# Altium schematic sheet power.SchDoc(power)
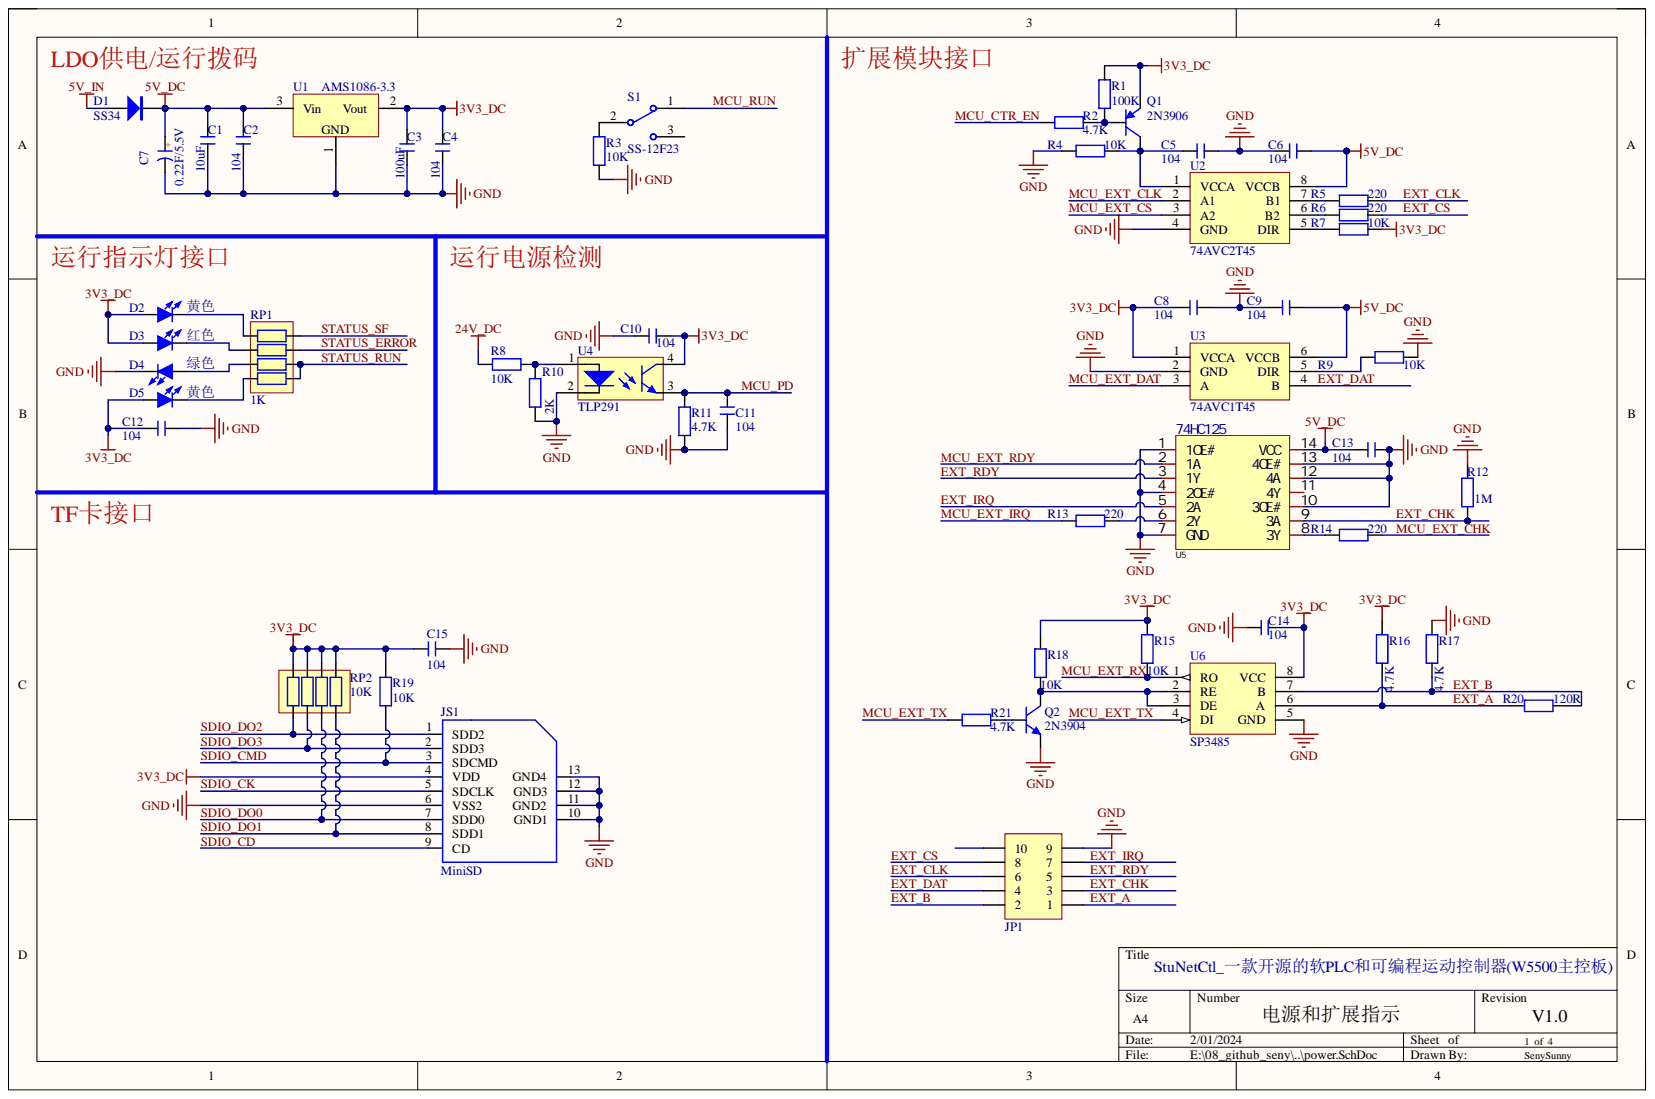
[diagram p0: power.SchDoc]

## Parts
C1  10uF  [C 1206]
C2  104  [C 0603]
C3  100uF  [C 1206]
C4  104  [C 0603]
C5  104  [C 0603]
C6  104  [C 0603]
C7  0.22F/5.5V  [CAP-TH_L14.5-W8.0-P10.0-D1.0]
C8  104  [C 0603]
C9  104  [C 0603]
C10  104  [C 0603]
C11  104  [C 0603]
C12  104  [C 0603]
C13  104  [C 0603]
C14  104  [C 0603]
C15  104  [C 0603]
D1  SS34  [SMA(DO214AC)]
D2  黄色  [LED 0805Y]
D3  红色  [LED 0805R]
D4  绿色  [LED 0805G]
D5  黄色  [LED 0805Y]
JP1  Header 5X2  [IDC2.54-10P_SMD]  (value not drawn)
JS1  MiniSD  [MiniSD-CARD2]
Q1  2N3906  [SOT23-3N]
Q2  2N3904  [SOT23-3N]
R1  100K  [R 0603]
R2  4.7K  [R 0603]
R3  10K  [R 0603]
R4  10K  [R 0603]
R5  220  [R 0603]
R6  220  [R 0603]
R7  10K  [R 0603]
R8  10K  [R 0603]
R9  10K  [R 0603]
R10  2K  [R 0603]
R11  4.7K  [R 0603]
R12  1M  [R 0603]
R13  220  [R 0603]
R14  220  [R 0603]
R15  10K  [R 0603]
R16  4.7K  [R 0603]
R17  4.7K  [R 0603]
R18  10K  [R 0603]
R19  10K  [R 0603]
R20  120R  [R 0603]
R21  4.7K  [R 0603]
RP1  1K  [RCA-8P4R-0603]
RP2  10K  [RCA-8P4R-0603]
S1  SS-12F23  [SS-12D07]
U1  AMS1086-3.3  [TO252]
U2  74AVC2T45  [VSSOP-8_2x2.3x05P]
U3  74AVC1T45  [SOT23-6N]
U4  TLP291  [SOP4_L_P1.27]
U5  74HC125  [TSSOP14_N]
U6  SP3485  [SOP8_L]

## Nets
3V3_DC: C3.1 C4.1 C8.2 C10.1 C12.2 C14.1 C15.1 D2.1 D3.1 D5.1 JS1.4(VDD) Q1.2 R1.2 R7.2 R15.2 R16.1 R18.2 R19.2 RP2.1 RP2.2 RP2.3 RP2.4 U1.2 U3.1(VCCA) U4.4 U6.8(VCC)
5V_DC: C1.1 C2.1 C6.1 C7.1 C9.1 C13.2 D1.2 U1.3 U2.8(VCCB) U3.6(VCCB) U5.14(VCC)
5V_IN: D1.1
24V_DC: R8.2
GND: C1.2 C2.2 C3.2 C4.2 C5.1 C6.2 C7.2 C8.1 C9.2 C10.2 C11.2 C12.1 C13.1 C14.2 C15.2 D4.2 JP1.9 JS1.6(VSS2) JS1.10(GND1) JS1.11(GND2) JS1.12(GND3) JS1.13(GND4) Q2.2 R3.2 R4.1 R9.1 R10.2 R11.2 R12.1 R17.1 U1.1 U2.4(GND) U3.2(GND) U4.2 U5.1(1OE#) U5.4(2OE#) U5.7(GND) U5.10(3OE#) U5.12(4A) U5.13(4OE#) U6.5(GND)
EXT_A: JP1.1 R16.2 R20.1 U6.6(A)
EXT_B: JP1.2 R17.2 R20.2 U6.7(B)
EXT_CHK: JP1.3 R12.2 U5.9(3A)
EXT_CLK: JP1.6 R5.2
EXT_CS: JP1.8 R6.2
EXT_DAT: JP1.4 U3.4(B)
EXT_IRQ: JP1.7 U5.5(2A)
EXT_RDY: JP1.5 U5.3(1Y)
MCU_CTR_EN: R2.1
MCU_EXT_CHK: R14.2
MCU_EXT_CLK: U2.2(A1)
MCU_EXT_CS: U2.3(A2)
MCU_EXT_DAT: U3.3(A)
MCU_EXT_IRQ: R13.1
MCU_EXT_RDY: U5.2(1A)
MCU_EXT_RX: R15.1 U6.1(RO)
MCU_EXT_TX: R21.2 U6.4(DI)
MCU_PD: C11.1 R11.1 U4.3
MCU_RUN: S1.1
SDIO_CD: JS1.9(CD)
SDIO_CK: JS1.5(SDCLK)
SDIO_CMD: JS1.3(SDCMD) R19.1
SDIO_DO0: JS1.7(SDD0) RP2.7
SDIO_DO1: JS1.8(SDD1) RP2.8
SDIO_DO2: JS1.1(SDD2) RP2.5
SDIO_DO3: JS1.2(SDD3) RP2.6
STATUS_ERROR: RP1.7
STATUS_RUN: RP1.5 RP1.6
STATUS_SF: RP1.8
NetC5_2: C5.2 Q1.3 R4.2 U2.1(VCCA)
NetD2_2: D2.2 RP1.1
NetD3_2: D3.2 RP1.2
NetD4_1: D4.1 RP1.3
NetD5_2: D5.2 RP1.4
NetQ1_1: Q1.1 R1.1 R2.2
NetQ2_1: Q2.1 R21.1
NetQ2_3: Q2.3 R18.1 U6.2(RE) U6.3(DE)
NetR3_1: R3.1 S1.2
NetR5_1: R5.1 U2.7(B1)
NetR6_1: R6.1 U2.6(B2)
NetR7_1: R7.1 U2.5(DIR)
NetR8_1: R8.1 R10.1 U4.1
NetR9_2: R9.2 U3.5(DIR)
NetR13_2: R13.2 U5.6(2Y)
NetR14_1: R14.1 U5.8(3Y)

Not connected: JP1 10; S1 3; U5 11(4Y)

## Library data of the parts
C1-C6 C8-C15 = C: 无极性贴片电容; 版权归属: 源创客; BOM名称: 无极性贴片电容; Component Kind: Standard; 学习资源: www.ooocke.com; Library Name: zblib_v1.IntLib
C7 = C-TH: 法拉电容，超级电容器; BOM名称: 贴片钽电容; 版权归属: 源创客; Component Kind: Standard; 学习资源: www.ooocke.com; Library Name: zblib_v1.IntLib
D1 = SS14: 肖特基二极管; 属性: 40V/1A; 版权归属: 源创客; BOM名称: 肖特基二极管; Component Kind: Standard; 学习资源: www.ooocke.com; Library Name: zblib_v1.IntLib
D2-D5 = LED-SMD: 贴片LED; 版权归属: 源创客; BOM名称: 贴片LED; Component Kind: Standard; 学习资源: www.ooocke.com; Library Name: zblib_v1.IntLib
JP1 = Header 5X2: 5*2P接插件; 版权归属: 源创客; BOM名称: 5*2P接插件; Component Kind: Standard; 学习资源: www.ooocke.com; Library Name: zblib_v1.IntLib
JS1 = MiniSD: miniSD卡，TF卡; Component Kind: Standard; Library Name: zblib_v1.IntLib
Q1 = 8550-SMD: 高频放大-PNP型; 属性: 40V 1.5A 1W 100MHZ; 版权归属: 源创客; BOM名称: 高频放大-PNP型; Component Kind: Standard; 学习资源: www.ooocke.com; Library Name: zblib_v1.IntLib
Q2 = 8050-SMD: 高频放大-NPN型; 属性: 40V 1.5A 1W 100MHZ; 版权归属: 源创客; BOM名称: 高频放大-NPN型; Component Kind: Standard; 学习资源: www.ooocke.com; Library Name: zblib_v1.IntLib
R1-R21 = R: 贴片电阻; 版权归属: 源创客; BOM名称: 贴片电阻; Component Kind: Standard; 学习资源: www.ooocke.com; Library Name: zblib_v1.IntLib
RP1 RP2 = R-8P4R: 贴片网络排阻; 版权归属: 源创客; BOM名称: 贴片网络排阻; Component Kind: Standard; 学习资源: www.ooocke.com; Library Name: zblib_v1.IntLib
S1 = SSW-1P: 1路波动开关; BOM名称: 波动开关; 版权归属: 源创客; Component Kind: Standard; 学习资源: www.ooocke.com; Library Name: zblib_v1.IntLib
U1 = AMS1117: 三端稳压芯片; BOM名称: 稳压芯片; 版权归属: 源创客; Component Kind: Standard; 学习资源: www.ooocke.com; Library Name: zblib_v1.IntLib
U2 = 74AVC2T45: 1.6V~5V电平转换芯片; Component Kind: Standard; Library Name: zblib_v1.IntLib
U3 = 74AVC1T45: 1.6V~5V电平转换芯片; Component Kind: Standard; Library Name: zblib_v1.IntLib
U4 = TLP291: 光耦，小体积，间距50mil; Component Kind: Standard; Library Name: zblib_v1.IntLib
U5 = 74HC125: 三态输出的四路总线缓冲器; Manufacturer: TI(德州仪器); Add into BOM: yes; Current-OutputHigh: 7.8mA; Device: SN74HC125PWR; output type: 三态; Supply Voltage: 2V~6V; Component Kind: Standard; Convert to PCB: yes; Current-OutputLow: 7.8mA; Datasheet: https://atta.szlcsc.com/upload/public/pdf/source/20170930/C132994_1506736365150997346.pdf; Input type: -; JLCPCB Part Class: Extended Part; LCSC Part Name: SN74HC125PWR; Manufacturer Part: SN74HC125PWR; Name: SN74HC125PWR; Operating temperature: -40℃~+85℃; Supplier: LCSC; Supplier Footprint: TSSOP-14; Supplier Part: C132994; Symbol: SN74HC125PWR; Type: 缓冲器，非反相; Library Name: zblib_v1.IntLib
U6 = SP3485: RS485总线收发芯片; 版权归属: 源创客; BOM名称: RS485总线收发芯片; Component Kind: Standard; 学习资源: www.ooocke.com; Library Name: zblib_v1.IntLib
